# Altium schematic sheet USB-RS485-unit.SchDoc
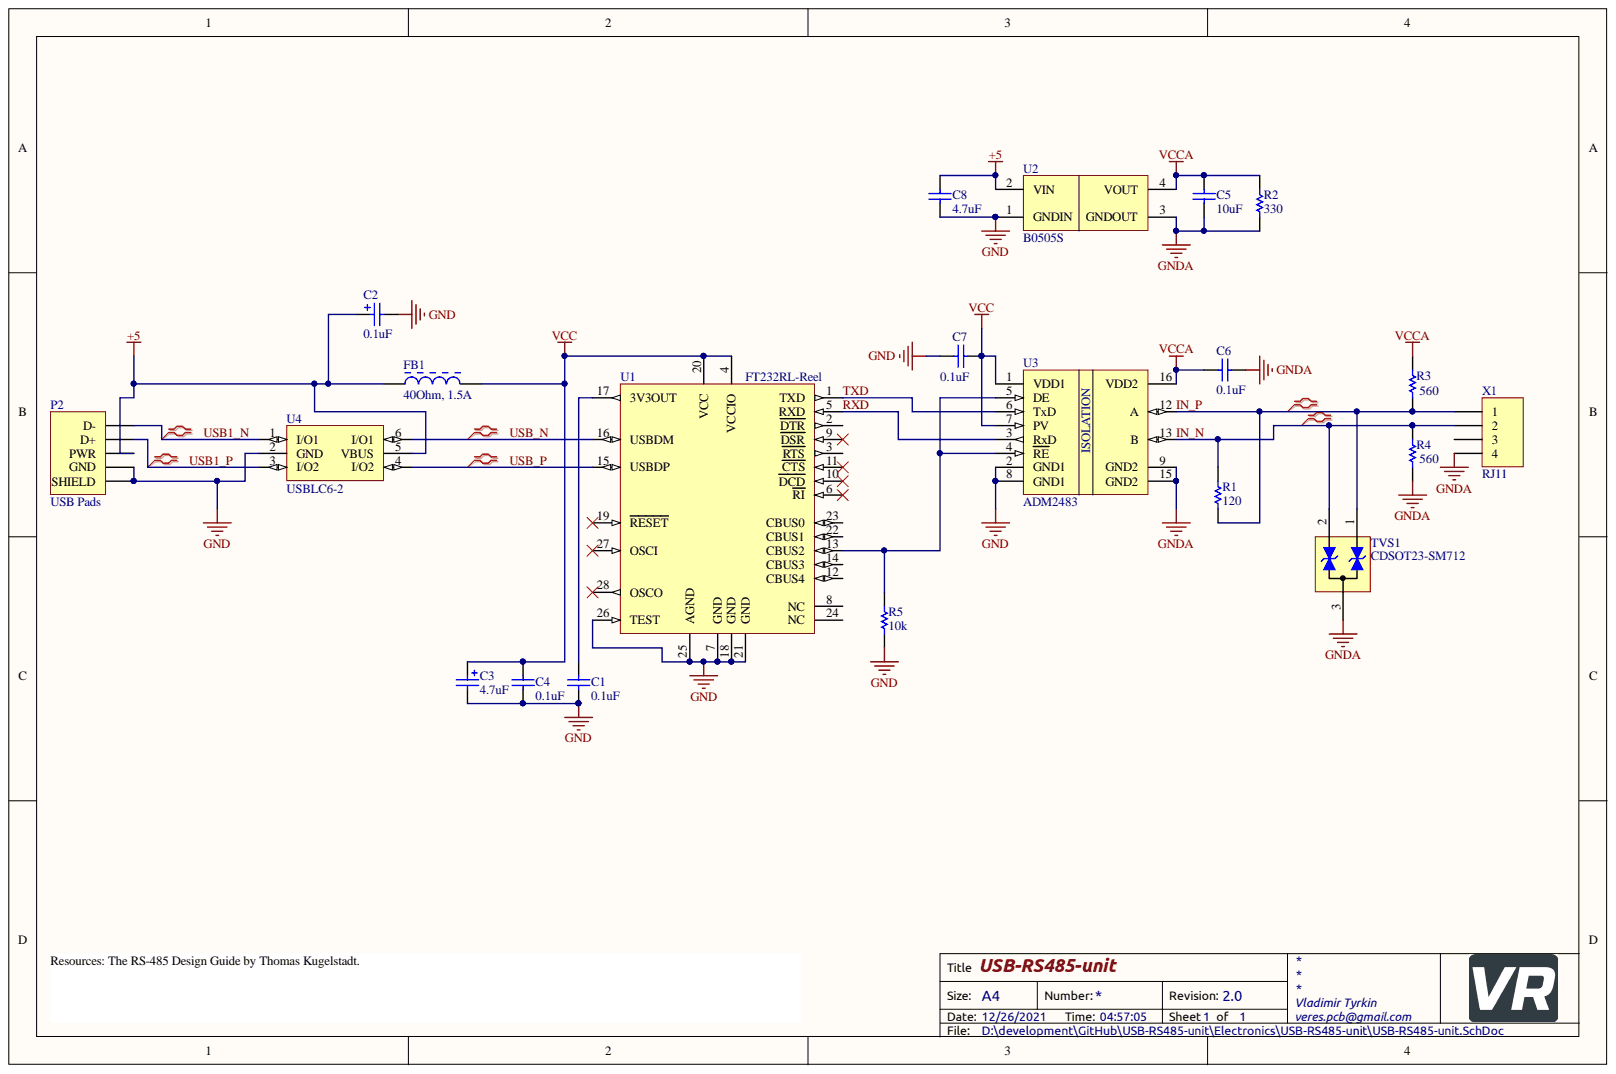
[diagram p0: USB-RS485-unit.SchDoc]

## Parts
C1  0.1uF  [C1206]
C2  0.1uF  [TC3216-1206]
C3  4.7uF  [TC3216-1206]
C4  0.1uF  [C1206]
C5  10uF  [C1206]
C6  0.1uF  [C1206]
C7  0.1uF  [C1206]
C8  4.7uF  [C1206]
FB1  40Ohm, 1.5A  Rated Current: 1.5A  [WE-CBF_0805_High Speed]
P2  USB Pads  [USB soldering pads]  (no BOM)
R1  120  [RES-0805]
R2  330  [RES-1206]
R3  560  [RES-0805]
R4  560  [RES-0805]
R5  10k  [RES-0805]
TVS1  CDSOT23-SM712  [SOT-23B_N]
U1  FT232RL-Reel  [SSOP-28_L]
U2  B0505S  [B0505S - horizontal]
U3  ADM2483  [SOL-16 Wide]
U4  USBLC6-2  [SOT23-6]
X1  RJ11  [RJ11]

## Nets
+5: C2.1 C8.2 FB1.1 P2.3(PWR) U2.2(VIN) U4.5(VBUS)
GND: C1.1 C2.2 C3.2 C4.1 C7.1 C8.1 P2.4(GND) P2.5(SHIELD) R5.1 U1.7(GND) U1.18(GND) U1.21(GND) U1.25(AGND) U1.26(TEST) U2.1(GNDIN) U3.2(GND1) U3.8(GND1) U4.2(GND)
GNDA: C5.1 C6.1 R2.1 R4.1 TVS1.3 U2.3(GNDOUT) U3.9(GND2) U3.15(GND2) X1.4
VCC: C3.1 C4.2 C7.2 FB1.2 U1.4(VCCIO) U1.20(VCC) U3.1(VDD1) U3.7(PV)
VCCA: C5.2 C6.2 R2.2 R3.2 U2.4(VOUT) U3.16(VDD2)
IN_N: R1.2 R4.2 TVS1.2 U3.13(B) X1.2
IN_P: R1.1 R3.1 TVS1.1 U3.12(A) X1.1
RXD: U1.5(RXD) U3.3(RxD)
TXD: U1.1(TXD) U3.6(TxD)
USB1_N: P2.1(D-) U4.1(I/O1)
USB1_P: P2.2(D+) U4.3(I/O2)
USB_N: U1.16(USBDM) U4.6(I/O1)
USB_P: U1.15(USBDP) U4.4(I/O2)
NetC1_2: C1.2 U1.17(3V3OUT)
NetR5_2: R5.2 U1.13(CBUS2) U3.4(RE) U3.5(DE)

Not connected: U1 2(DTR) 3(RTS) 6(RI) 8(NC) 9(DSR) 10(DCD) 11(CTS) 12(CBUS4) 14(CBUS3) 19(RESET) 22(CBUS1) 23(CBUS0) 24(NC) 27(OSCI) 28(OSCO); X1 3

## Library data of the parts
C1 C4 C6 C7 = Cap: Capacitor ceramic SMT; Manufacturer: Murata; Manufacturer_Part_Number: GRM319R72A104KA01D; Part_Type: Chip Capacitor; Order_Code_ChipIDip: 9000697437; Component Kind: Standard; Library Name: USB-RS485-unit.SCHLIB; Signal Integrity: Cap Semi; Simulation: CAP; Value: 0.1uF
C2 = Cap Polarized: Polarized Capacitor SMT; Manufacturer: TECAP; Manufacturer_Part_Number: TECAP0.1/35VA10; Order_Code_ChipIDip: 1654; Voltage: 35; Component Kind: Standard; Library Name: USB-RS485-unit.SCHLIB; Part_Type: Polarized Capacitor; Signal Integrity: Cap Pol; Simulation: CAP; Value: 0.1uF
C3 = Cap Polarized: Polarized Capacitor SMT; Manufacturer: TECAP; Manufacturer_Part_Number: TECAP4.7/10VA10; Order_Code_ChipIDip: 6038; Component Kind: Standard; Library Name: USB-RS485-unit.SCHLIB; Part_Type: Polarized Capacitor; Signal Integrity: Cap Pol; Simulation: CAP; Value: 4.7uF
C5 = Cap: Capacitor ceramic SMT; Manufacturer: Murata; Manufacturer_Part_Number: GRM31CR61E106KA12L; Part_Type: Chip Capacitor; Order_Code_ChipIDip: 9000122641; Component Kind: Standard; Library Name: USB-RS485-unit.SCHLIB; Signal Integrity: Cap Semi; Simulation: CAP; Value: 10uF
C8 = Cap: Capacitor ceramic SMT; Manufacturer: Murata; Manufacturer_Part_Number: GRM31CR71E475KA88L; Part_Type: Chip Capacitor; Order_Code_ChipIDip: 9000122604; Component Kind: Standard; Library Name: USB-RS485-unit.SCHLIB; Signal Integrity: Cap Semi; Simulation: CAP; Value: 4.7uF
FB1 = 7427920: Ferrite Bead; Manufacturer: Laird Technologies; Manufacturer_Part_Number: MI0805K400R-10; DC Resistance max.: 0.15Ohm; Order_Code_ChipIDip: 9000618736; Component Kind: Standard; Impedance @100MHz: 40Ohm; Impedance max.: 40Ohm; Library Name: WE-FerriteBead (rev21a).IntLib; Manufacturer Part Number: MI0805K400R-10; Mounting Technology: SMT; Operating Temperature: -55 to +125degC; Packaging: Tape and Reel; Size: 0805
P2 = USB pads: Pads for soldering USB cable; Part_Type: Mechanical; Library Name: USB-RS485-unit.SCHLIB; Signal Integrity: Connector; Value: USB Pads
R1 = Res: Rectangular Thick Film Chip Resistor; Manufacturer: Yageo; Manufacturer_Part_Number: RC0805FR-07120RL; Order_Code_ChipIDip: 9000079464; Component Kind: Standard; ComponentLink1Description: Manufacturer Link; ComponentLink1URL: http://www.panasonic.com/industrial/components/resistive_products.htm; ComponentLink2Description: Datasheet; ComponentLink2URL: http://www.panasonic.com/industrial/components/pdf/AOA0000CE8.pdf; Library Name: USB-RS485-unit.SCHLIB; Part_Type: Resistor; Signal Integrity: Res; Simulation: RESISTOR; Value: 120
R2 = Res: Rectangular Thick Film Chip Resistor; Manufacturer: Yageo; Manufacturer_Part_Number: RC0805FR-07330RL; Order_Code_ChipIDip: 9000079474; Component Kind: Standard; ComponentLink1Description: Manufacturer Link; ComponentLink1URL: http://www.panasonic.com/industrial/components/resistive_products.htm; ComponentLink2Description: Datasheet; ComponentLink2URL: http://www.panasonic.com/industrial/components/pdf/AOA0000CE8.pdf; Library Name: USB-RS485-unit.SCHLIB; Part_Type: Resistor; Signal Integrity: Res; Simulation: RESISTOR; Value: 330
R3 R4 = Res: Rectangular Thick Film Chip Resistor; Manufacturer: Yageo; Manufacturer_Part_Number: RC0805FR-07560RL; Order_Code_ChipIDip: 9000079480; Component Kind: Standard; ComponentLink1Description: Manufacturer Link; ComponentLink1URL: http://www.panasonic.com/industrial/components/resistive_products.htm; ComponentLink2Description: Datasheet; ComponentLink2URL: http://www.panasonic.com/industrial/components/pdf/AOA0000CE8.pdf; Library Name: USB-RS485-unit.SCHLIB; Part_Type: Resistor; Signal Integrity: Res; Simulation: RESISTOR; Value: 560
R5 = Res: Rectangular Thick Film Chip Resistor; Manufacturer: Yageo; Manufacturer_Part_Number: RC0805FR-0710KL; Order_Code_ChipIDip: 9000079514; Component Kind: Standard; ComponentLink1Description: Manufacturer Link; ComponentLink1URL: http://www.panasonic.com/industrial/components/resistive_products.htm; ComponentLink2Description: Datasheet; ComponentLink2URL: http://www.panasonic.com/industrial/components/pdf/AOA0000CE8.pdf; Library Name: USB-RS485-unit.SCHLIB; Part_Type: Resistor; Signal Integrity: Res; Simulation: RESISTOR; Value: 10k
TVS1 = (no symbol name): Surface Mount TVS Diode; Manufacturer: Bourns; Order_Code_ChipIDip: 9000168919; Component Kind: Standard; ComponentLink1Description: Datasheet; ComponentLink1URL: http://tunmcdsvn.tun.st.com/Component_Datasheet_Library/Trunk/Diodes/STM_ESD_ESDA6V1BC6; Library Name: USB-RS485-unit.SCHLIB; Manufacturer_Part_Number: CDSOT23-SM712; Package: SOT-23; Part_Type: TVS; Value: CDSOT23-SM712
U1 = FT232RL-Reel: USB UART Asynchronous Serial Data Transfer Chip, SSOP-28, Tape and Reel; Manufacturer: FTDI; Part_Type: Driver; Order_Code_ChipIDip: 201330607; Component Kind: Standard; ComponentLink1Description: Manufacturer Link; ComponentLink1URL: http://www.ftdichip.com/Products/ICs/FT232R.htm; ComponentLink2Description: Datasheet; ComponentLink2URL: http://www.ftdichip.com/Support/Documents/DataSheets/ICs/DS_FT232R.pdf; Library Name: FTDI Communication UART.IntLib; Manufacturer_Part_Number: FT232RL-Reel; Value: FT232RL-Reel
U2 = (no symbol name): Isolated DC-DC Converter; Manufacturer: Mornsun Power; Manufacturer_Part_Number: B0505S-1WR2; Order_Code_ChipIDip: 9000304516; Component Kind: Standard; ComponentLink1Description: Datasheet; ComponentLink1URL: http://tunmcdsvn.tun.st.com/Component_Datasheet_Library/Trunk/Diodes/STM_ESD_ESDA6V1BC6; Library Name: USB-RS485-unit.SCHLIB; Package: SIP; Part_Type: DC-DC; Value: B0505S
U3 = (no symbol name): Half-Duplex, Isolated RS-485 Transceiver; Manufacturer: STMICROELECTRONICS; Manufacturer_Part_Number: ADM2483BRWZ-REEL; Order_Code_ChipIDip: 9000375326; Component Kind: Standard; ComponentLink1Description: Datasheet; ComponentLink1URL: http://tunmcdsvn.tun.st.com/Component_Datasheet_Library/Trunk/Diodes/STM_ESD_ESDA6V1BC6; Library Name: USB-RS485-unit.SCHLIB; Package: SOIC-W; Part_Type: Transceiver; Value: ADM2483
U4 = (no symbol name): Quad Bidir ESD protection; Manufacturer: STMICROELECTRONICS; Manufacturer_Part_Number: USBLC6-2SC6; Order_Code_ChipIDip: 9000039051; Component Kind: Standard; ComponentLink1Description: Datasheet; ComponentLink1URL: http://tunmcdsvn.tun.st.com/Component_Datasheet_Library/Trunk/Diodes/STM_ESD_ESDA6V1BC6; Library Name: USB-RS485-unit.SCHLIB; Part_Type: ESD Protection; Status: Released; Value: USBLC6-2
X1 = RJ11: RJ-11 Connector, 4-Pin; Manufacturer: Connfly; Manufacturer_Part_Number: DS1132-S40BPX; Order_Code_ChipIDip: 9000190984; Component Kind: Standard; Library Name: USB-RS485-unit.SCHLIB; Part_Type: Connector; Signal Integrity: Connector; Value: RJ11
